# Altium schematic sheet LED_Matrix16x16.SchDoc
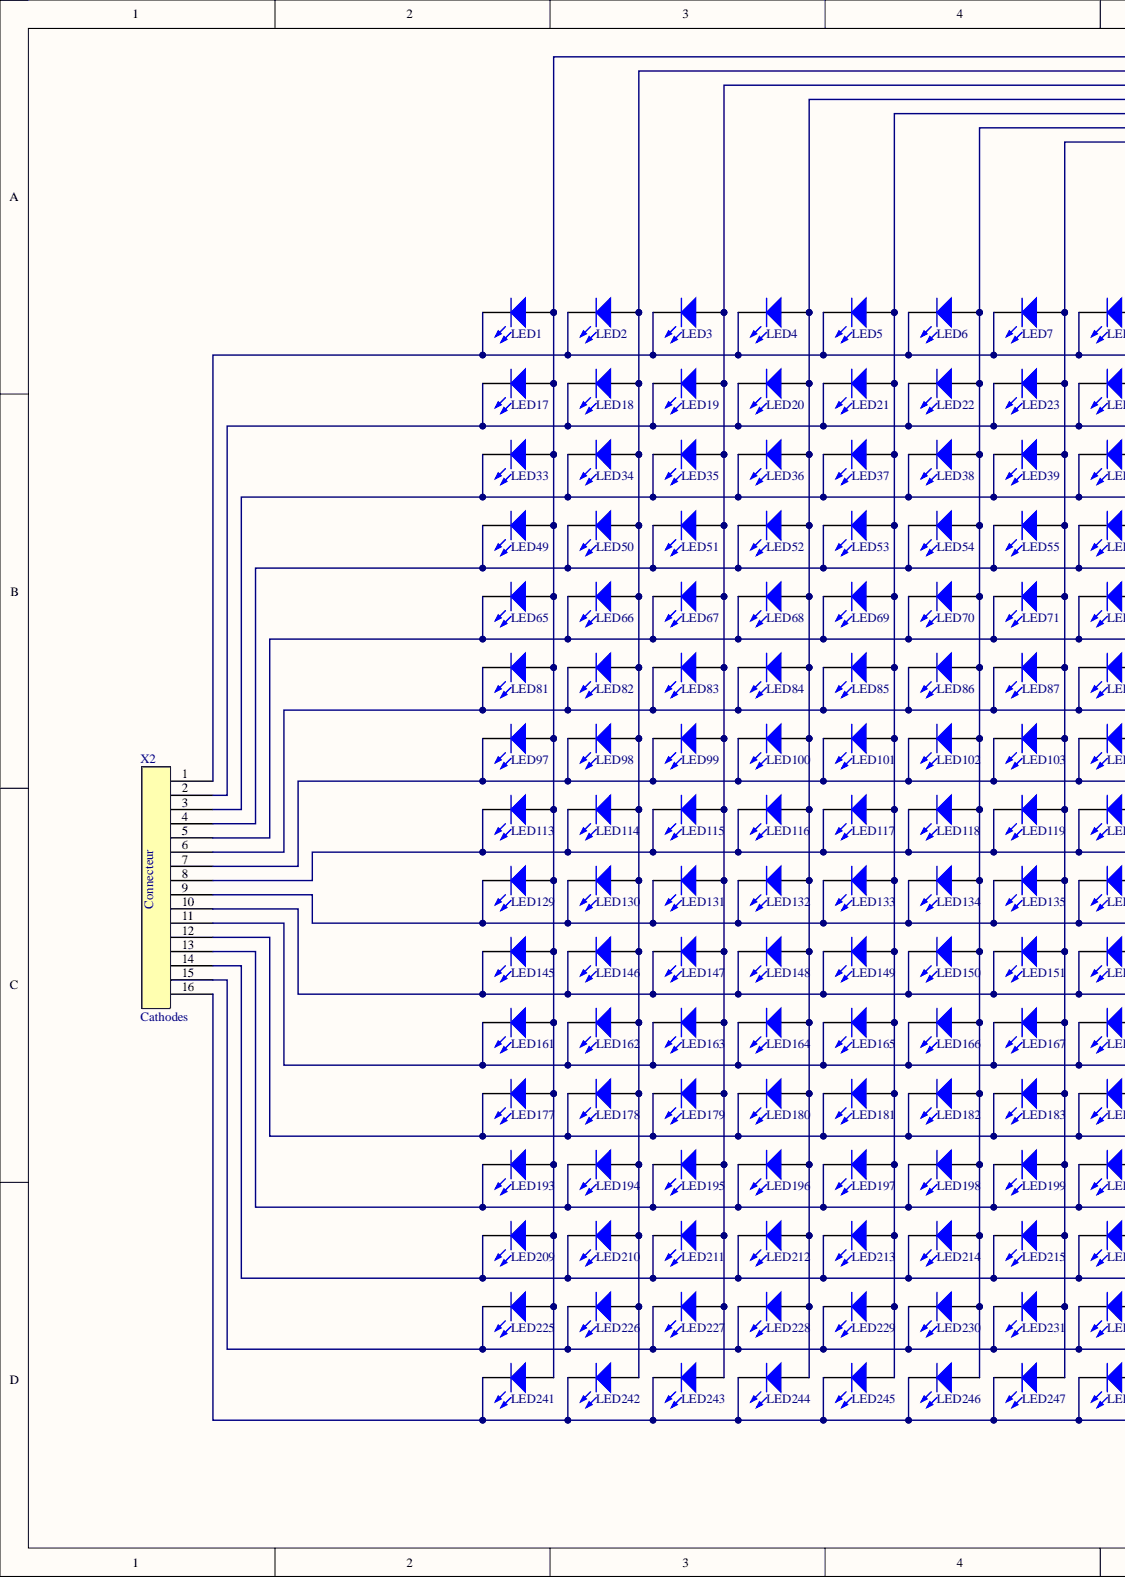
[diagram p0: LED_Matrix16x16.SchDoc, left]
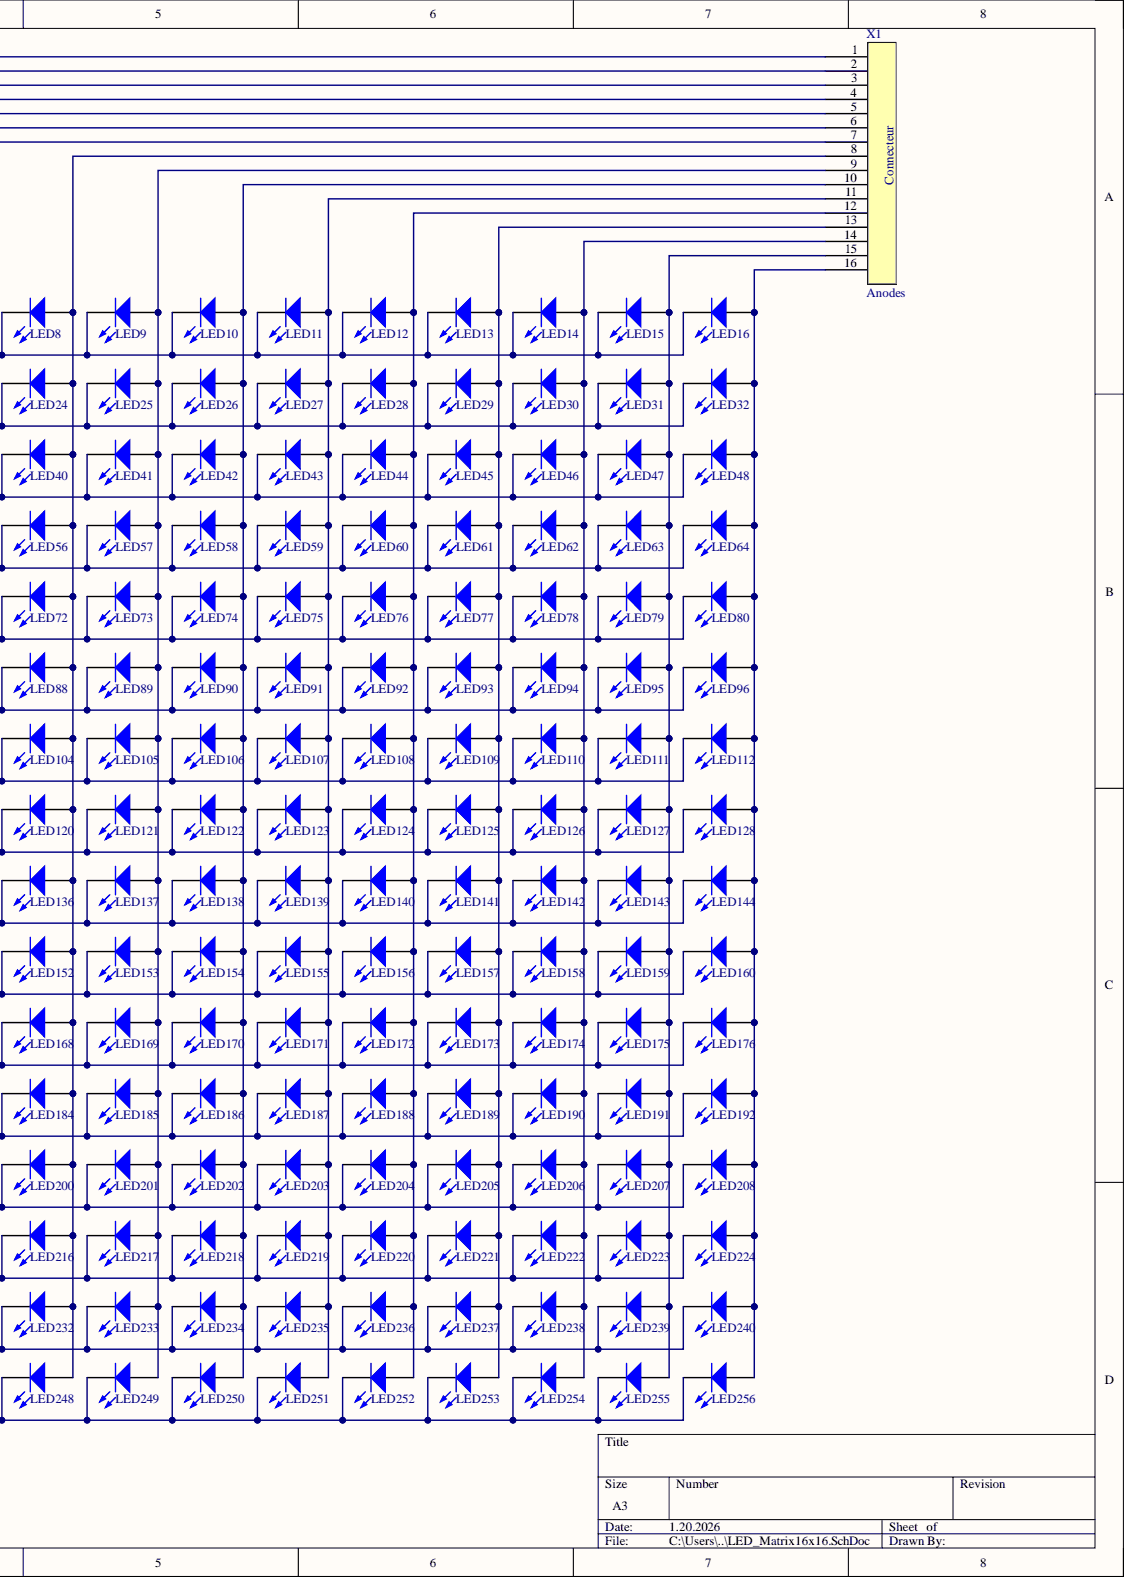
[diagram p1: LED_Matrix16x16.SchDoc, right]

## Parts
LED1  KPT-2012SRC-PRV  [0805_LED]  (value not drawn)
LED2  KPT-2012SRC-PRV  [0805_LED]  (value not drawn)
LED3  KPT-2012SRC-PRV  [0805_LED]  (value not drawn)
LED4  KPT-2012SRC-PRV  [0805_LED]  (value not drawn)
LED5  KPT-2012SRC-PRV  [0805_LED]  (value not drawn)
LED6  KPT-2012SRC-PRV  [0805_LED]  (value not drawn)
LED7  KPT-2012SRC-PRV  [0805_LED]  (value not drawn)
LED8  KPT-2012SRC-PRV  [0805_LED]  (value not drawn)
LED9  KPT-2012SRC-PRV  [0805_LED]  (value not drawn)
LED10  KPT-2012SRC-PRV  [0805_LED]  (value not drawn)
LED11  KPT-2012SRC-PRV  [0805_LED]  (value not drawn)
LED12  KPT-2012SRC-PRV  [0805_LED]  (value not drawn)
LED13  KPT-2012SRC-PRV  [0805_LED]  (value not drawn)
LED14  KPT-2012SRC-PRV  [0805_LED]  (value not drawn)
LED15  KPT-2012SRC-PRV  [0805_LED]  (value not drawn)
LED16  KPT-2012SRC-PRV  [0805_LED]  (value not drawn)
LED17  KPT-2012SRC-PRV  [0805_LED]  (value not drawn)
LED18  KPT-2012SRC-PRV  [0805_LED]  (value not drawn)
LED19  KPT-2012SRC-PRV  [0805_LED]  (value not drawn)
LED20  KPT-2012SRC-PRV  [0805_LED]  (value not drawn)
LED21  KPT-2012SRC-PRV  [0805_LED]  (value not drawn)
LED22  KPT-2012SRC-PRV  [0805_LED]  (value not drawn)
LED23  KPT-2012SRC-PRV  [0805_LED]  (value not drawn)
LED24  KPT-2012SRC-PRV  [0805_LED]  (value not drawn)
LED25  KPT-2012SRC-PRV  [0805_LED]  (value not drawn)
LED26  KPT-2012SRC-PRV  [0805_LED]  (value not drawn)
LED27  KPT-2012SRC-PRV  [0805_LED]  (value not drawn)
LED28  KPT-2012SRC-PRV  [0805_LED]  (value not drawn)
LED29  KPT-2012SRC-PRV  [0805_LED]  (value not drawn)
LED30  KPT-2012SRC-PRV  [0805_LED]  (value not drawn)
LED31  KPT-2012SRC-PRV  [0805_LED]  (value not drawn)
LED32  KPT-2012SRC-PRV  [0805_LED]  (value not drawn)
LED33  KPT-2012SRC-PRV  [0805_LED]  (value not drawn)
LED34  KPT-2012SRC-PRV  [0805_LED]  (value not drawn)
LED35  KPT-2012SRC-PRV  [0805_LED]  (value not drawn)
LED36  KPT-2012SRC-PRV  [0805_LED]  (value not drawn)
LED37  KPT-2012SRC-PRV  [0805_LED]  (value not drawn)
LED38  KPT-2012SRC-PRV  [0805_LED]  (value not drawn)
LED39  KPT-2012SRC-PRV  [0805_LED]  (value not drawn)
LED40  KPT-2012SRC-PRV  [0805_LED]  (value not drawn)
LED41  KPT-2012SRC-PRV  [0805_LED]  (value not drawn)
LED42  KPT-2012SRC-PRV  [0805_LED]  (value not drawn)
LED43  KPT-2012SRC-PRV  [0805_LED]  (value not drawn)
LED44  KPT-2012SRC-PRV  [0805_LED]  (value not drawn)
LED45  KPT-2012SRC-PRV  [0805_LED]  (value not drawn)
LED46  KPT-2012SRC-PRV  [0805_LED]  (value not drawn)
LED47  KPT-2012SRC-PRV  [0805_LED]  (value not drawn)
LED48  KPT-2012SRC-PRV  [0805_LED]  (value not drawn)
LED49  KPT-2012SRC-PRV  [0805_LED]  (value not drawn)
LED50  KPT-2012SRC-PRV  [0805_LED]  (value not drawn)
LED51  KPT-2012SRC-PRV  [0805_LED]  (value not drawn)
LED52  KPT-2012SRC-PRV  [0805_LED]  (value not drawn)
LED53  KPT-2012SRC-PRV  [0805_LED]  (value not drawn)
LED54  KPT-2012SRC-PRV  [0805_LED]  (value not drawn)
LED55  KPT-2012SRC-PRV  [0805_LED]  (value not drawn)
LED56  KPT-2012SRC-PRV  [0805_LED]  (value not drawn)
LED57  KPT-2012SRC-PRV  [0805_LED]  (value not drawn)
LED58  KPT-2012SRC-PRV  [0805_LED]  (value not drawn)
LED59  KPT-2012SRC-PRV  [0805_LED]  (value not drawn)
LED60  KPT-2012SRC-PRV  [0805_LED]  (value not drawn)
LED61  KPT-2012SRC-PRV  [0805_LED]  (value not drawn)
LED62  KPT-2012SRC-PRV  [0805_LED]  (value not drawn)
LED63  KPT-2012SRC-PRV  [0805_LED]  (value not drawn)
LED64  KPT-2012SRC-PRV  [0805_LED]  (value not drawn)
LED65  KPT-2012SRC-PRV  [0805_LED]  (value not drawn)
LED66  KPT-2012SRC-PRV  [0805_LED]  (value not drawn)
LED67  KPT-2012SRC-PRV  [0805_LED]  (value not drawn)
LED68  KPT-2012SRC-PRV  [0805_LED]  (value not drawn)
LED69  KPT-2012SRC-PRV  [0805_LED]  (value not drawn)
LED70  KPT-2012SRC-PRV  [0805_LED]  (value not drawn)
LED71  KPT-2012SRC-PRV  [0805_LED]  (value not drawn)
LED72  KPT-2012SRC-PRV  [0805_LED]  (value not drawn)
LED73  KPT-2012SRC-PRV  [0805_LED]  (value not drawn)
LED74  KPT-2012SRC-PRV  [0805_LED]  (value not drawn)
LED75  KPT-2012SRC-PRV  [0805_LED]  (value not drawn)
LED76  KPT-2012SRC-PRV  [0805_LED]  (value not drawn)
LED77  KPT-2012SRC-PRV  [0805_LED]  (value not drawn)
LED78  KPT-2012SRC-PRV  [0805_LED]  (value not drawn)
LED79  KPT-2012SRC-PRV  [0805_LED]  (value not drawn)
LED80  KPT-2012SRC-PRV  [0805_LED]  (value not drawn)
LED81  KPT-2012SRC-PRV  [0805_LED]  (value not drawn)
LED82  KPT-2012SRC-PRV  [0805_LED]  (value not drawn)
LED83  KPT-2012SRC-PRV  [0805_LED]  (value not drawn)
LED84  KPT-2012SRC-PRV  [0805_LED]  (value not drawn)
LED85  KPT-2012SRC-PRV  [0805_LED]  (value not drawn)
LED86  KPT-2012SRC-PRV  [0805_LED]  (value not drawn)
LED87  KPT-2012SRC-PRV  [0805_LED]  (value not drawn)
LED88  KPT-2012SRC-PRV  [0805_LED]  (value not drawn)
LED89  KPT-2012SRC-PRV  [0805_LED]  (value not drawn)
LED90  KPT-2012SRC-PRV  [0805_LED]  (value not drawn)
LED91  KPT-2012SRC-PRV  [0805_LED]  (value not drawn)
LED92  KPT-2012SRC-PRV  [0805_LED]  (value not drawn)
LED93  KPT-2012SRC-PRV  [0805_LED]  (value not drawn)
LED94  KPT-2012SRC-PRV  [0805_LED]  (value not drawn)
LED95  KPT-2012SRC-PRV  [0805_LED]  (value not drawn)
LED96  KPT-2012SRC-PRV  [0805_LED]  (value not drawn)
LED97  KPT-2012SRC-PRV  [0805_LED]  (value not drawn)
LED98  KPT-2012SRC-PRV  [0805_LED]  (value not drawn)
LED99  KPT-2012SRC-PRV  [0805_LED]  (value not drawn)
LED100  KPT-2012SRC-PRV  [0805_LED]  (value not drawn)
LED101  KPT-2012SRC-PRV  [0805_LED]  (value not drawn)
LED102  KPT-2012SRC-PRV  [0805_LED]  (value not drawn)
LED103  KPT-2012SRC-PRV  [0805_LED]  (value not drawn)
LED104  KPT-2012SRC-PRV  [0805_LED]  (value not drawn)
LED105  KPT-2012SRC-PRV  [0805_LED]  (value not drawn)
LED106  KPT-2012SRC-PRV  [0805_LED]  (value not drawn)
LED107  KPT-2012SRC-PRV  [0805_LED]  (value not drawn)
LED108  KPT-2012SRC-PRV  [0805_LED]  (value not drawn)
LED109  KPT-2012SRC-PRV  [0805_LED]  (value not drawn)
LED110  KPT-2012SRC-PRV  [0805_LED]  (value not drawn)
LED111  KPT-2012SRC-PRV  [0805_LED]  (value not drawn)
LED112  KPT-2012SRC-PRV  [0805_LED]  (value not drawn)
LED113  KPT-2012SRC-PRV  [0805_LED]  (value not drawn)
LED114  KPT-2012SRC-PRV  [0805_LED]  (value not drawn)
LED115  KPT-2012SRC-PRV  [0805_LED]  (value not drawn)
LED116  KPT-2012SRC-PRV  [0805_LED]  (value not drawn)
LED117  KPT-2012SRC-PRV  [0805_LED]  (value not drawn)
LED118  KPT-2012SRC-PRV  [0805_LED]  (value not drawn)
LED119  KPT-2012SRC-PRV  [0805_LED]  (value not drawn)
LED120  KPT-2012SRC-PRV  [0805_LED]  (value not drawn)
LED121  KPT-2012SRC-PRV  [0805_LED]  (value not drawn)
LED122  KPT-2012SRC-PRV  [0805_LED]  (value not drawn)
LED123  KPT-2012SRC-PRV  [0805_LED]  (value not drawn)
LED124  KPT-2012SRC-PRV  [0805_LED]  (value not drawn)
LED125  KPT-2012SRC-PRV  [0805_LED]  (value not drawn)
LED126  KPT-2012SRC-PRV  [0805_LED]  (value not drawn)
LED127  KPT-2012SRC-PRV  [0805_LED]  (value not drawn)
LED128  KPT-2012SRC-PRV  [0805_LED]  (value not drawn)
LED129  KPT-2012SRC-PRV  [0805_LED]  (value not drawn)
LED130  KPT-2012SRC-PRV  [0805_LED]  (value not drawn)
LED131  KPT-2012SRC-PRV  [0805_LED]  (value not drawn)
LED132  KPT-2012SRC-PRV  [0805_LED]  (value not drawn)
LED133  KPT-2012SRC-PRV  [0805_LED]  (value not drawn)
LED134  KPT-2012SRC-PRV  [0805_LED]  (value not drawn)
LED135  KPT-2012SRC-PRV  [0805_LED]  (value not drawn)
LED136  KPT-2012SRC-PRV  [0805_LED]  (value not drawn)
LED137  KPT-2012SRC-PRV  [0805_LED]  (value not drawn)
LED138  KPT-2012SRC-PRV  [0805_LED]  (value not drawn)
LED139  KPT-2012SRC-PRV  [0805_LED]  (value not drawn)
LED140  KPT-2012SRC-PRV  [0805_LED]  (value not drawn)
LED141  KPT-2012SRC-PRV  [0805_LED]  (value not drawn)
LED142  KPT-2012SRC-PRV  [0805_LED]  (value not drawn)
LED143  KPT-2012SRC-PRV  [0805_LED]  (value not drawn)
LED144  KPT-2012SRC-PRV  [0805_LED]  (value not drawn)
LED145  KPT-2012SRC-PRV  [0805_LED]  (value not drawn)
LED146  KPT-2012SRC-PRV  [0805_LED]  (value not drawn)
LED147  KPT-2012SRC-PRV  [0805_LED]  (value not drawn)
LED148  KPT-2012SRC-PRV  [0805_LED]  (value not drawn)
LED149  KPT-2012SRC-PRV  [0805_LED]  (value not drawn)
LED150  KPT-2012SRC-PRV  [0805_LED]  (value not drawn)
LED151  KPT-2012SRC-PRV  [0805_LED]  (value not drawn)
LED152  KPT-2012SRC-PRV  [0805_LED]  (value not drawn)
LED153  KPT-2012SRC-PRV  [0805_LED]  (value not drawn)
LED154  KPT-2012SRC-PRV  [0805_LED]  (value not drawn)
LED155  KPT-2012SRC-PRV  [0805_LED]  (value not drawn)
LED156  KPT-2012SRC-PRV  [0805_LED]  (value not drawn)
LED157  KPT-2012SRC-PRV  [0805_LED]  (value not drawn)
LED158  KPT-2012SRC-PRV  [0805_LED]  (value not drawn)
LED159  KPT-2012SRC-PRV  [0805_LED]  (value not drawn)
LED160  KPT-2012SRC-PRV  [0805_LED]  (value not drawn)
LED161  KPT-2012SRC-PRV  [0805_LED]  (value not drawn)
LED162  KPT-2012SRC-PRV  [0805_LED]  (value not drawn)
LED163  KPT-2012SRC-PRV  [0805_LED]  (value not drawn)
LED164  KPT-2012SRC-PRV  [0805_LED]  (value not drawn)
LED165  KPT-2012SRC-PRV  [0805_LED]  (value not drawn)
LED166  KPT-2012SRC-PRV  [0805_LED]  (value not drawn)
LED167  KPT-2012SRC-PRV  [0805_LED]  (value not drawn)
LED168  KPT-2012SRC-PRV  [0805_LED]  (value not drawn)
LED169  KPT-2012SRC-PRV  [0805_LED]  (value not drawn)
LED170  KPT-2012SRC-PRV  [0805_LED]  (value not drawn)
LED171  KPT-2012SRC-PRV  [0805_LED]  (value not drawn)
LED172  KPT-2012SRC-PRV  [0805_LED]  (value not drawn)
LED173  KPT-2012SRC-PRV  [0805_LED]  (value not drawn)
LED174  KPT-2012SRC-PRV  [0805_LED]  (value not drawn)
LED175  KPT-2012SRC-PRV  [0805_LED]  (value not drawn)
LED176  KPT-2012SRC-PRV  [0805_LED]  (value not drawn)
LED177  KPT-2012SRC-PRV  [0805_LED]  (value not drawn)
LED178  KPT-2012SRC-PRV  [0805_LED]  (value not drawn)
LED179  KPT-2012SRC-PRV  [0805_LED]  (value not drawn)
LED180  KPT-2012SRC-PRV  [0805_LED]  (value not drawn)
LED181  KPT-2012SRC-PRV  [0805_LED]  (value not drawn)
LED182  KPT-2012SRC-PRV  [0805_LED]  (value not drawn)
LED183  KPT-2012SRC-PRV  [0805_LED]  (value not drawn)
LED184  KPT-2012SRC-PRV  [0805_LED]  (value not drawn)
LED185  KPT-2012SRC-PRV  [0805_LED]  (value not drawn)
LED186  KPT-2012SRC-PRV  [0805_LED]  (value not drawn)
LED187  KPT-2012SRC-PRV  [0805_LED]  (value not drawn)
LED188  KPT-2012SRC-PRV  [0805_LED]  (value not drawn)
LED189  KPT-2012SRC-PRV  [0805_LED]  (value not drawn)
LED190  KPT-2012SRC-PRV  [0805_LED]  (value not drawn)
LED191  KPT-2012SRC-PRV  [0805_LED]  (value not drawn)
LED192  KPT-2012SRC-PRV  [0805_LED]  (value not drawn)
LED193  KPT-2012SRC-PRV  [0805_LED]  (value not drawn)
LED194  KPT-2012SRC-PRV  [0805_LED]  (value not drawn)
LED195  KPT-2012SRC-PRV  [0805_LED]  (value not drawn)
LED196  KPT-2012SRC-PRV  [0805_LED]  (value not drawn)
LED197  KPT-2012SRC-PRV  [0805_LED]  (value not drawn)
LED198  KPT-2012SRC-PRV  [0805_LED]  (value not drawn)
LED199  KPT-2012SRC-PRV  [0805_LED]  (value not drawn)
LED200  KPT-2012SRC-PRV  [0805_LED]  (value not drawn)
LED201  KPT-2012SRC-PRV  [0805_LED]  (value not drawn)
LED202  KPT-2012SRC-PRV  [0805_LED]  (value not drawn)
LED203  KPT-2012SRC-PRV  [0805_LED]  (value not drawn)
LED204  KPT-2012SRC-PRV  [0805_LED]  (value not drawn)
LED205  KPT-2012SRC-PRV  [0805_LED]  (value not drawn)
LED206  KPT-2012SRC-PRV  [0805_LED]  (value not drawn)
LED207  KPT-2012SRC-PRV  [0805_LED]  (value not drawn)
LED208  KPT-2012SRC-PRV  [0805_LED]  (value not drawn)
LED209  KPT-2012SRC-PRV  [0805_LED]  (value not drawn)
LED210  KPT-2012SRC-PRV  [0805_LED]  (value not drawn)
LED211  KPT-2012SRC-PRV  [0805_LED]  (value not drawn)
LED212  KPT-2012SRC-PRV  [0805_LED]  (value not drawn)
LED213  KPT-2012SRC-PRV  [0805_LED]  (value not drawn)
LED214  KPT-2012SRC-PRV  [0805_LED]  (value not drawn)
LED215  KPT-2012SRC-PRV  [0805_LED]  (value not drawn)
LED216  KPT-2012SRC-PRV  [0805_LED]  (value not drawn)
LED217  KPT-2012SRC-PRV  [0805_LED]  (value not drawn)
LED218  KPT-2012SRC-PRV  [0805_LED]  (value not drawn)
LED219  KPT-2012SRC-PRV  [0805_LED]  (value not drawn)
LED220  KPT-2012SRC-PRV  [0805_LED]  (value not drawn)
LED221  KPT-2012SRC-PRV  [0805_LED]  (value not drawn)
LED222  KPT-2012SRC-PRV  [0805_LED]  (value not drawn)
LED223  KPT-2012SRC-PRV  [0805_LED]  (value not drawn)
LED224  KPT-2012SRC-PRV  [0805_LED]  (value not drawn)
LED225  KPT-2012SRC-PRV  [0805_LED]  (value not drawn)
LED226  KPT-2012SRC-PRV  [0805_LED]  (value not drawn)
LED227  KPT-2012SRC-PRV  [0805_LED]  (value not drawn)
LED228  KPT-2012SRC-PRV  [0805_LED]  (value not drawn)
LED229  KPT-2012SRC-PRV  [0805_LED]  (value not drawn)
LED230  KPT-2012SRC-PRV  [0805_LED]  (value not drawn)
LED231  KPT-2012SRC-PRV  [0805_LED]  (value not drawn)
LED232  KPT-2012SRC-PRV  [0805_LED]  (value not drawn)
LED233  KPT-2012SRC-PRV  [0805_LED]  (value not drawn)
LED234  KPT-2012SRC-PRV  [0805_LED]  (value not drawn)
LED235  KPT-2012SRC-PRV  [0805_LED]  (value not drawn)
LED236  KPT-2012SRC-PRV  [0805_LED]  (value not drawn)
LED237  KPT-2012SRC-PRV  [0805_LED]  (value not drawn)
LED238  KPT-2012SRC-PRV  [0805_LED]  (value not drawn)
LED239  KPT-2012SRC-PRV  [0805_LED]  (value not drawn)
LED240  KPT-2012SRC-PRV  [0805_LED]  (value not drawn)
LED241  KPT-2012SRC-PRV  [0805_LED]  (value not drawn)
LED242  KPT-2012SRC-PRV  [0805_LED]  (value not drawn)
LED243  KPT-2012SRC-PRV  [0805_LED]  (value not drawn)
LED244  KPT-2012SRC-PRV  [0805_LED]  (value not drawn)
LED245  KPT-2012SRC-PRV  [0805_LED]  (value not drawn)
LED246  KPT-2012SRC-PRV  [0805_LED]  (value not drawn)
LED247  KPT-2012SRC-PRV  [0805_LED]  (value not drawn)
LED248  KPT-2012SRC-PRV  [0805_LED]  (value not drawn)
LED249  KPT-2012SRC-PRV  [0805_LED]  (value not drawn)
LED250  KPT-2012SRC-PRV  [0805_LED]  (value not drawn)
LED251  KPT-2012SRC-PRV  [0805_LED]  (value not drawn)
LED252  KPT-2012SRC-PRV  [0805_LED]  (value not drawn)
LED253  KPT-2012SRC-PRV  [0805_LED]  (value not drawn)
LED254  KPT-2012SRC-PRV  [0805_LED]  (value not drawn)
LED255  KPT-2012SRC-PRV  [0805_LED]  (value not drawn)
LED256  KPT-2012SRC-PRV  [0805_LED]  (value not drawn)
X1  Anodes  [CON8x8(edited)]
X2  Cathodes  [CON8x8(edited)]

## Nets
NetLED1_1: LED1.1 LED2.1 LED3.1 LED4.1 LED5.1 LED6.1 LED7.1 LED8.1 LED9.1 LED10.1 LED11.1 LED12.1 LED13.1 LED14.1 LED15.1 LED16.1 X2.1
NetLED1_2: LED1.2 LED17.2 LED33.2 LED49.2 LED65.2 LED81.2 LED97.2 LED113.2 LED129.2 LED145.2 LED161.2 LED177.2 LED193.2 LED209.2 LED225.2 LED241.2 X1.1
NetLED2_2: LED2.2 LED18.2 LED34.2 LED50.2 LED66.2 LED82.2 LED98.2 LED114.2 LED130.2 LED146.2 LED162.2 LED178.2 LED194.2 LED210.2 LED226.2 LED242.2 X1.2
NetLED3_2: LED3.2 LED19.2 LED35.2 LED51.2 LED67.2 LED83.2 LED99.2 LED115.2 LED131.2 LED147.2 LED163.2 LED179.2 LED195.2 LED211.2 LED227.2 LED243.2 X1.3
NetLED4_2: LED4.2 LED20.2 LED36.2 LED52.2 LED68.2 LED84.2 LED100.2 LED116.2 LED132.2 LED148.2 LED164.2 LED180.2 LED196.2 LED212.2 LED228.2 LED244.2 X1.4
NetLED5_2: LED5.2 LED21.2 LED37.2 LED53.2 LED69.2 LED85.2 LED101.2 LED117.2 LED133.2 LED149.2 LED165.2 LED181.2 LED197.2 LED213.2 LED229.2 LED245.2 X1.5
NetLED6_2: LED6.2 LED22.2 LED38.2 LED54.2 LED70.2 LED86.2 LED102.2 LED118.2 LED134.2 LED150.2 LED166.2 LED182.2 LED198.2 LED214.2 LED230.2 LED246.2 X1.6
NetLED7_2: LED7.2 LED23.2 LED39.2 LED55.2 LED71.2 LED87.2 LED103.2 LED119.2 LED135.2 LED151.2 LED167.2 LED183.2 LED199.2 LED215.2 LED231.2 LED247.2 X1.7
NetLED8_2: LED8.2 LED24.2 LED40.2 LED56.2 LED72.2 LED88.2 LED104.2 LED120.2 LED136.2 LED152.2 LED168.2 LED184.2 LED200.2 LED216.2 LED232.2 LED248.2 X1.8
NetLED9_2: LED9.2 LED25.2 LED41.2 LED57.2 LED73.2 LED89.2 LED105.2 LED121.2 LED137.2 LED153.2 LED169.2 LED185.2 LED201.2 LED217.2 LED233.2 LED249.2 X1.9
NetLED10_2: LED10.2 LED26.2 LED42.2 LED58.2 LED74.2 LED90.2 LED106.2 LED122.2 LED138.2 LED154.2 LED170.2 LED186.2 LED202.2 LED218.2 LED234.2 LED250.2 X1.10
NetLED11_2: LED11.2 LED27.2 LED43.2 LED59.2 LED75.2 LED91.2 LED107.2 LED123.2 LED139.2 LED155.2 LED171.2 LED187.2 LED203.2 LED219.2 LED235.2 LED251.2 X1.11
NetLED12_2: LED12.2 LED28.2 LED44.2 LED60.2 LED76.2 LED92.2 LED108.2 LED124.2 LED140.2 LED156.2 LED172.2 LED188.2 LED204.2 LED220.2 LED236.2 LED252.2 X1.12
NetLED13_2: LED13.2 LED29.2 LED45.2 LED61.2 LED77.2 LED93.2 LED109.2 LED125.2 LED141.2 LED157.2 LED173.2 LED189.2 LED205.2 LED221.2 LED237.2 LED253.2 X1.13
NetLED14_2: LED14.2 LED30.2 LED46.2 LED62.2 LED78.2 LED94.2 LED110.2 LED126.2 LED142.2 LED158.2 LED174.2 LED190.2 LED206.2 LED222.2 LED238.2 LED254.2 X1.14
NetLED15_2: LED15.2 LED31.2 LED47.2 LED63.2 LED79.2 LED95.2 LED111.2 LED127.2 LED143.2 LED159.2 LED175.2 LED191.2 LED207.2 LED223.2 LED239.2 LED255.2 X1.15
NetLED16_2: LED16.2 LED32.2 LED48.2 LED64.2 LED80.2 LED96.2 LED112.2 LED128.2 LED144.2 LED160.2 LED176.2 LED192.2 LED208.2 LED224.2 LED240.2 LED256.2 X1.16
NetLED17_1: LED17.1 LED18.1 LED19.1 LED20.1 LED21.1 LED22.1 LED23.1 LED24.1 LED25.1 LED26.1 LED27.1 LED28.1 LED29.1 LED30.1 LED31.1 LED32.1 X2.2
NetLED33_1: LED33.1 LED34.1 LED35.1 LED36.1 LED37.1 LED38.1 LED39.1 LED40.1 LED41.1 LED42.1 LED43.1 LED44.1 LED45.1 LED46.1 LED47.1 LED48.1 X2.3
NetLED49_1: LED49.1 LED50.1 LED51.1 LED52.1 LED53.1 LED54.1 LED55.1 LED56.1 LED57.1 LED58.1 LED59.1 LED60.1 LED61.1 LED62.1 LED63.1 LED64.1 X2.4
NetLED65_1: LED65.1 LED66.1 LED67.1 LED68.1 LED69.1 LED70.1 LED71.1 LED72.1 LED73.1 LED74.1 LED75.1 LED76.1 LED77.1 LED78.1 LED79.1 LED80.1 X2.5
NetLED81_1: LED81.1 LED82.1 LED83.1 LED84.1 LED85.1 LED86.1 LED87.1 LED88.1 LED89.1 LED90.1 LED91.1 LED92.1 LED93.1 LED94.1 LED95.1 LED96.1 X2.6
NetLED97_1: LED97.1 LED98.1 LED99.1 LED100.1 LED101.1 LED102.1 LED103.1 LED104.1 LED105.1 LED106.1 LED107.1 LED108.1 LED109.1 LED110.1 LED111.1 LED112.1 X2.7
NetLED113_1: LED113.1 LED114.1 LED115.1 LED116.1 LED117.1 LED118.1 LED119.1 LED120.1 LED121.1 LED122.1 LED123.1 LED124.1 LED125.1 LED126.1 LED127.1 LED128.1 X2.8
NetLED129_1: LED129.1 LED130.1 LED131.1 LED132.1 LED133.1 LED134.1 LED135.1 LED136.1 LED137.1 LED138.1 LED139.1 LED140.1 LED141.1 LED142.1 LED143.1 LED144.1 X2.9
NetLED145_1: LED145.1 LED146.1 LED147.1 LED148.1 LED149.1 LED150.1 LED151.1 LED152.1 LED153.1 LED154.1 LED155.1 LED156.1 LED157.1 LED158.1 LED159.1 LED160.1 X2.10
NetLED161_1: LED161.1 LED162.1 LED163.1 LED164.1 LED165.1 LED166.1 LED167.1 LED168.1 LED169.1 LED170.1 LED171.1 LED172.1 LED173.1 LED174.1 LED175.1 LED176.1 X2.11
NetLED177_1: LED177.1 LED178.1 LED179.1 LED180.1 LED181.1 LED182.1 LED183.1 LED184.1 LED185.1 LED186.1 LED187.1 LED188.1 LED189.1 LED190.1 LED191.1 LED192.1 X2.12
NetLED193_1: LED193.1 LED194.1 LED195.1 LED196.1 LED197.1 LED198.1 LED199.1 LED200.1 LED201.1 LED202.1 LED203.1 LED204.1 LED205.1 LED206.1 LED207.1 LED208.1 X2.13
NetLED209_1: LED209.1 LED210.1 LED211.1 LED212.1 LED213.1 LED214.1 LED215.1 LED216.1 LED217.1 LED218.1 LED219.1 LED220.1 LED221.1 LED222.1 LED223.1 LED224.1 X2.14
NetLED225_1: LED225.1 LED226.1 LED227.1 LED228.1 LED229.1 LED230.1 LED231.1 LED232.1 LED233.1 LED234.1 LED235.1 LED236.1 LED237.1 LED238.1 LED239.1 LED240.1 X2.15
NetLED241_1: LED241.1 LED242.1 LED243.1 LED244.1 LED245.1 LED246.1 LED247.1 LED248.1 LED249.1 LED250.1 LED251.1 LED252.1 LED253.1 LED254.1 LED255.1 LED256.1 X2.16

## Library data of the parts
X1 X2 = Mâle DIN 8 x 8: Component Kind: Standard; Library Name: 2008_SablierElectronique_SCHLIB.SchLib
LED1-LED256 = KPT-2012SRC-PRV: Component Kind: Standard; Library Name: 2008_SablierElectronique_SCHLIB.SchLib
